# Altium schematic sheet USB.SchDoc(USB)
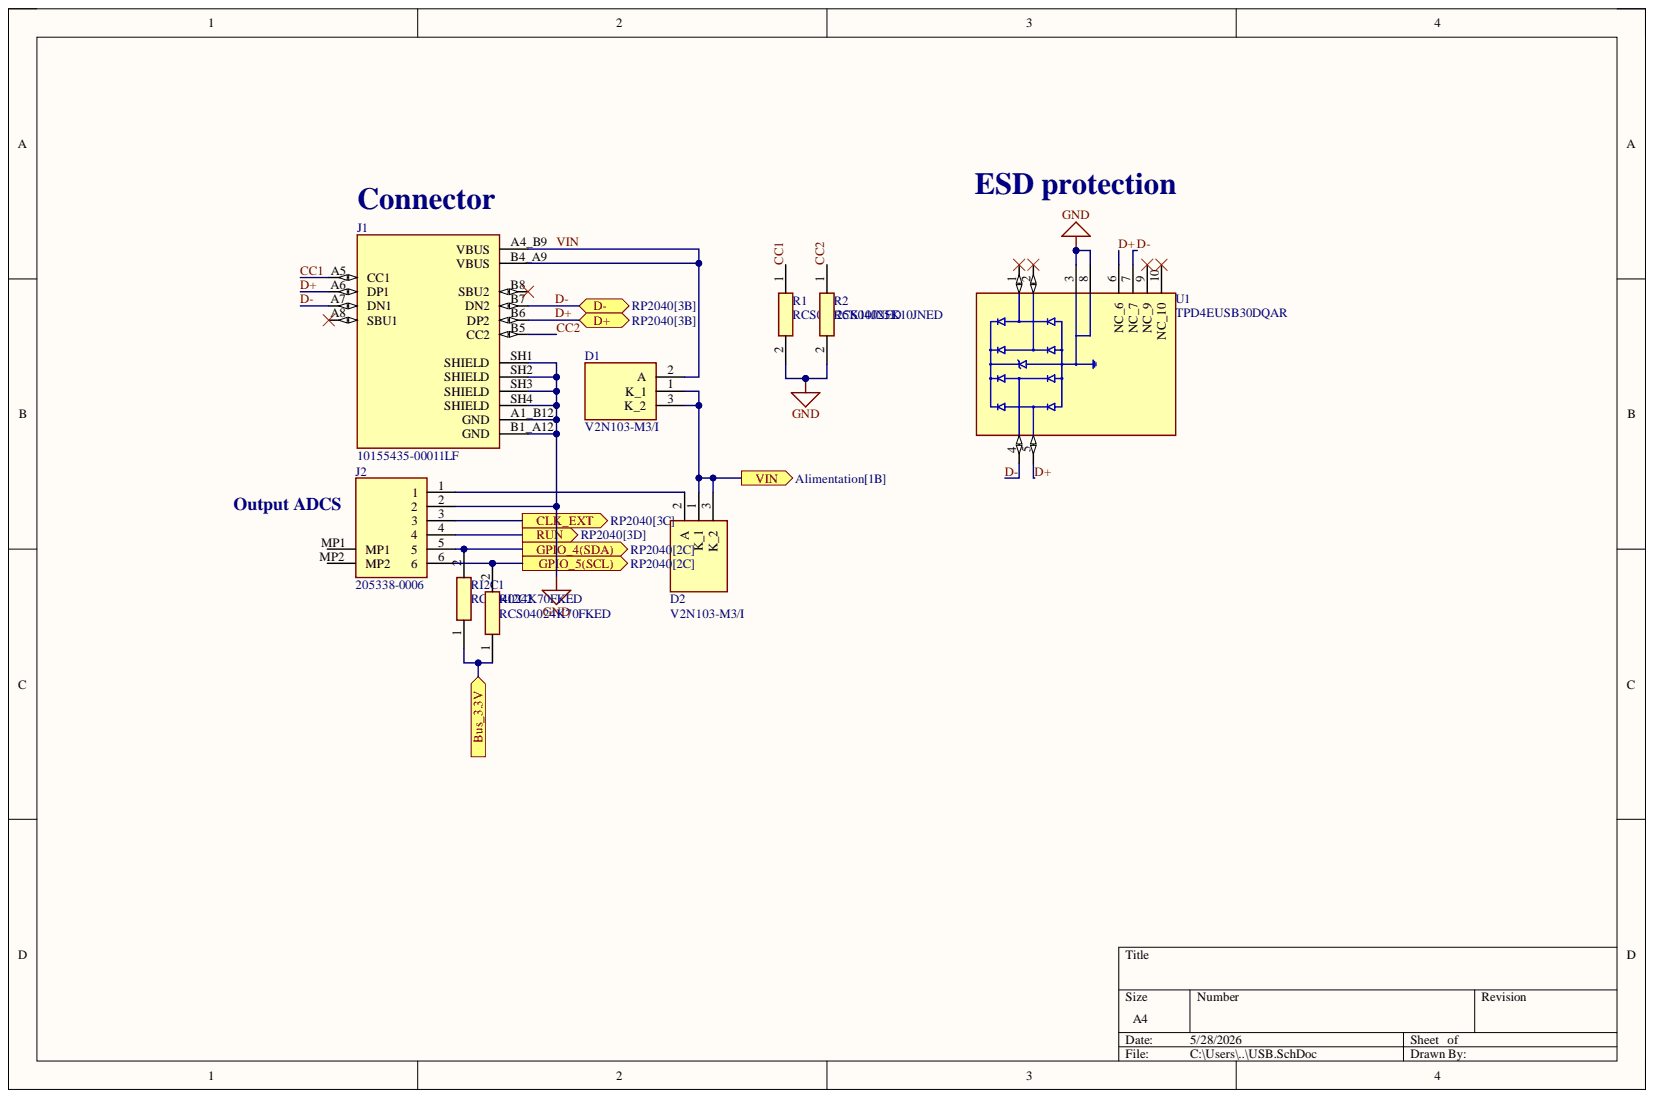
[diagram p0: USB.SchDoc]

## Parts
D1  V2N103-M3/I  [6DFN18AM3I]
D2  V2N103-M3/I  [6DFN18AM3I]
J1  10155435-00011LF  [AMPHENOL_10155435-00011LF]
J2  205338-0006  [2053380006]
R1  RCS04025K10JNED  [RCS0402_E3]
R2  RCS04025K10JNED  [RCS0402_E3]
RI2C1  RCS04024K70FKED  [RCS0402_E3]
RI2C2  RCS04024K70FKED  [RCS0402_E3]
U1  TPD4EUSB30DQAR  [IC_TPD4EUSB30DQAR]

## Ports
Bus_3.3V, CLK_EXT, D+, D-, GPIO_4(SDA), GPIO_5(SCL), RUN, VIN

## Nets
GND: J1.A1_B12(GND) J1.B1_A12(GND) J1.SH1(SHIELD) J1.SH2(SHIELD) J1.SH3(SHIELD) J1.SH4(SHIELD) J2.2 R1.2 R2.2 U1.3 U1.8
Bus_3.3V: RI2C1.1 RI2C2.1 ; port Bus_3.3V
CC1: J1.A5(CC1) R1.1
CC2: J1.B5(CC2) R2.1
CLK_EXT: J2.3 ; port CLK_EXT
D+: J1.A6(DP1) J1.B6(DP2) U1.5 U1.6(NC_6) ; port D+
D-: J1.A7(DN1) J1.B7(DN2) U1.4 U1.7(NC_7) ; port D-
GPIO_4(SDA): J2.5 RI2C1.2 ; port GPIO_4(SDA)
GPIO_5(SCL): J2.6 RI2C2.2 ; port GPIO_5(SCL)
RUN: J2.4 ; port RUN
VIN: D1.2 J1.A4_B9(VBUS) J1.B4_A9(VBUS)
NetD1_1: D1.1 D1.3 D2.1 D2.3 ; port VIN
NetD2_2: D2.2 J2.1

Not connected: J1 A8(SBU1) B8(SBU2); J2 MP1 MP2; U1 1 2 9(NC_9) 10(NC_10)

## Library data of the parts
D1 D2 = V2NM153-M3_I: Schottky Diode; Manufacturer_Name: Vishay; Manufacturer_Part_Number: V2NM153-M3/I; Component Kind: Standard; Datasheet Link: https://www.vishay.com/docs/98375/v2nm153.pdf; Height: 0.98mm; Mouser Part Number: 78-V2NM153-M3/I; Mouser Price/Stock: https://www.mouser.co.uk/ProductDetail/Vishay-Semiconductors/V2NM153-M3-I?qs=amGC7iS6iy8NSFTlrO4tcw%3D%3D; Library Name: ADSC_V2.IntLib
J2 = 205338-0006: Connector; Manufacturer_Name: Molex; Component Kind: Standard; Datasheet Link: https://www.molex.com/pdm_docs/sd/2053380006_sd.pdf; Height: 2.8mm; Manufacturer_Part_Number: 205338-0006; Mouser Part Number: 538-205338-0006; Mouser Price/Stock: https://www.mouser.co.uk/ProductDetail/Molex/205338-0006?qs=GedFDFLaBXGqfCEYtQ%2Fm%2Fw%3D%3D; Library Name: ADSC_V2.IntLib
R1 R2 = RCS04025K10JNED: Resistor; Manufacturer_Name: Vishay; Component Kind: Standard; Datasheet Link: https://www.vishay.com/docs/20065/rcse3.pdf; Manufacturer_Part_Number: RCS04025K10JNED; Library Name: ADSC_V2.IntLib
RI2C1 RI2C2 = RCS04024K70FKED: Résistance 4.7kohm; Manufacturer_Name: Vishay; Arrow Part Number: RCS04024K70FKED; Arrow Price/Stock: https://www.arrow.com/en/products/rcs04024k70fked/vishay?utm_currency=USD&region=nac; Component Kind: Standard; Datasheet Link: https://www.vishay.com/docs/20065/rcse3.pdf; Manufacturer_Part_Number: RCS04024K70FKED; Mouser Part Number: 71-RCS04024K70FKED; Mouser Price/Stock: https://www.mouser.co.uk/ProductDetail/Vishay-Dale/RCS04024K70FKED?qs=NKmfXavxMaw%252Bu6VUO3JuMQ%3D%3D; Library Name: ADSC_V2.IntLib
U1 = TPD4EUSB30DQAR: 4-channel ESD solution for SuperSpeed (6 Gbps) USB 3.0 interface 10-USON -40 to 85; Availability: Unavailable; Component Kind: Standard; Library Name: TPD4EUSB30DQAR.IntLib; MP: TPD4EUSB30DQAR; Package: None; Price: None
J1 = 10155435-00011LF: Availability: Unavailable; Component Kind: Standard; Library Name: 10155435-00011LF.IntLib; MP: 10155435-00011LF; Package: None; Price: None
